# Altium schematic sheet #DISPLAY.SchDoc
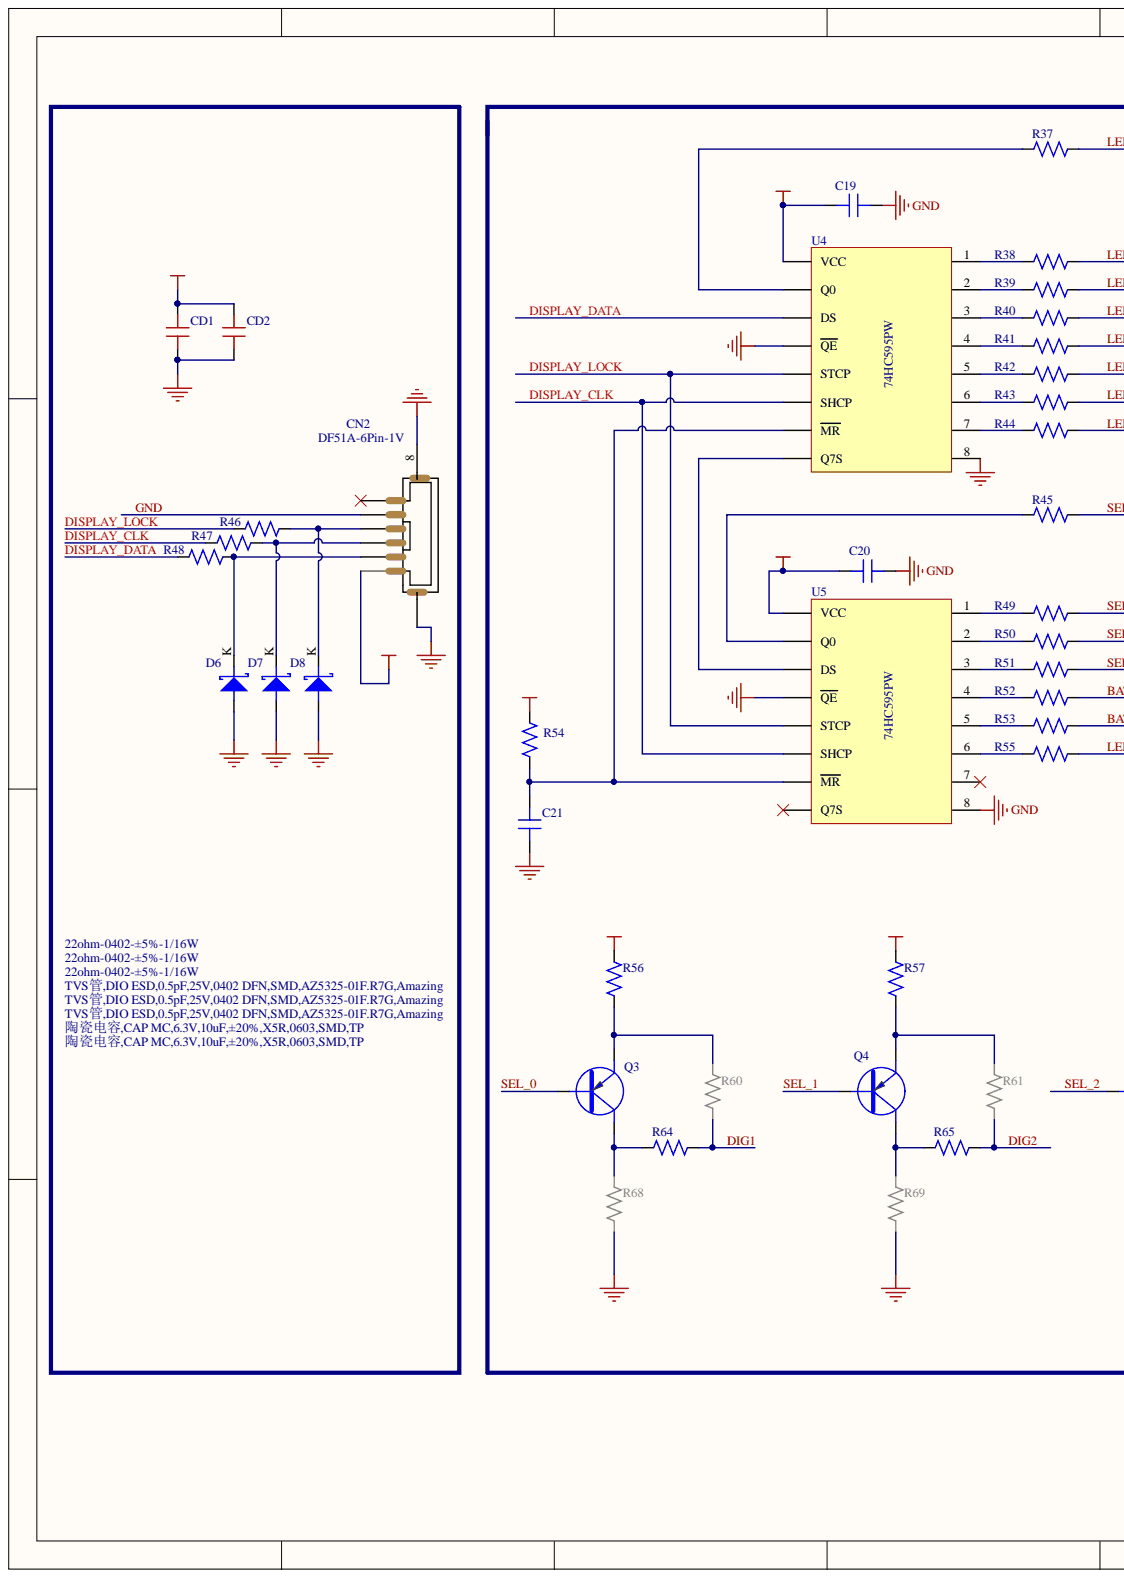
[diagram p0: #DISPLAY.SchDoc, left]
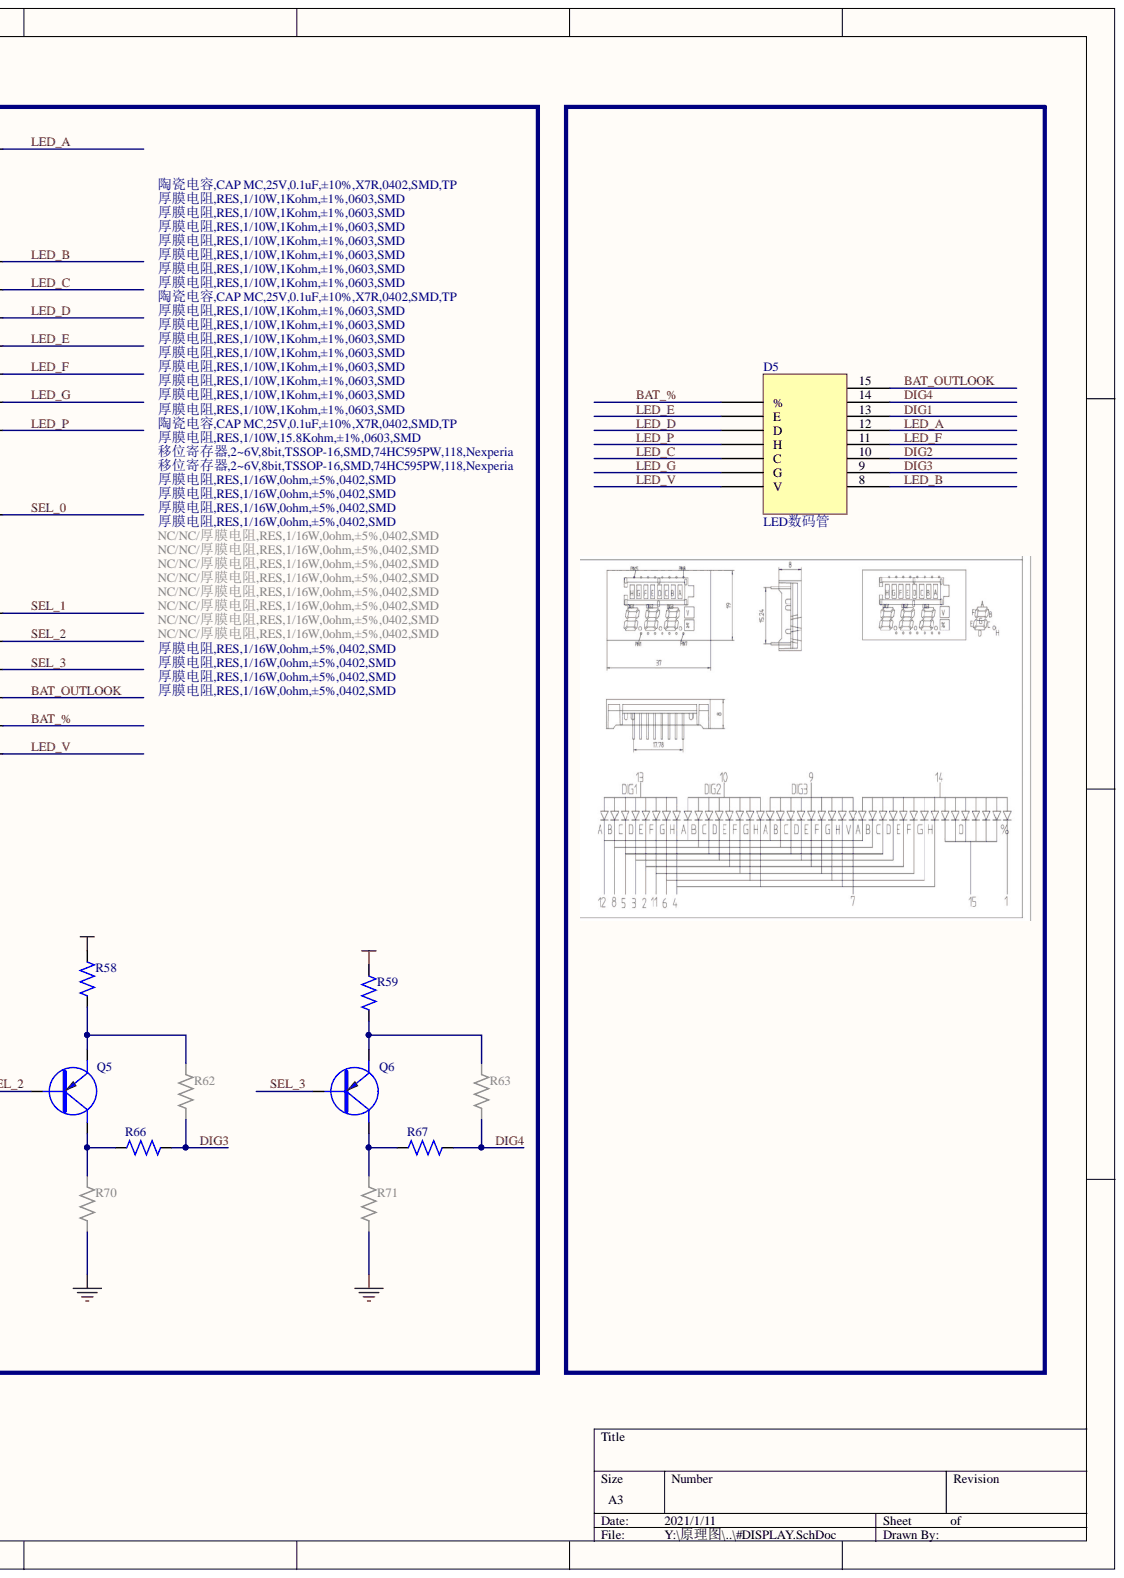
[diagram p1: #DISPLAY.SchDoc, right]

## Parts
C19  陶瓷电容,CAP MC,25V,0.1uF,±10%,X7R,0402,SMD,TP  [C0402]
C20  陶瓷电容,CAP MC,25V,0.1uF,±10%,X7R,0402,SMD,TP  [C0402]
C21  陶瓷电容,CAP MC,25V,0.1uF,±10%,X7R,0402,SMD,TP  [C0402]
CD1  陶瓷电容,CAP MC,6.3V,10uF,±20%,X5R,0603,SMD,TP  [C0603]
CD2  陶瓷电容,CAP MC,6.3V,10uF,±20%,X5R,0603,SMD,TP  [C0603]
CN2  DF51A-6Pin-1V  [SIP6V/1.0S-3]
D5  LED数码管  [LED]
D6  TVS管,DIO ESD,0.5pF,25V,0402 DFN,SMD,AZ5325-01F.R7G,Amazing  [DIODE-S0402]
D7  TVS管,DIO ESD,0.5pF,25V,0402 DFN,SMD,AZ5325-01F.R7G,Amazing  [DIODE-S0402]
D8  TVS管,DIO ESD,0.5pF,25V,0402 DFN,SMD,AZ5325-01F.R7G,Amazing  [DIODE-S0402]
Q3  通用三极管,Dynatron,PNP,0.2A,40V,30-100,SOT-23,MMBT3906-(100-300),BLUE ROCKET  [SOT-23]  (value not drawn)
Q4  通用三极管,Dynatron,PNP,0.2A,40V,30-100,SOT-23,MMBT3906-(100-300),BLUE ROCKET  [SOT-23]  (value not drawn)
Q5  通用三极管,Dynatron,PNP,0.2A,40V,30-100,SOT-23,MMBT3906-(100-300),BLUE ROCKET  [SOT-23]  (value not drawn)
Q6  通用三极管,Dynatron,PNP,0.2A,40V,30-100,SOT-23,MMBT3906-(100-300),BLUE ROCKET  [SOT-23]  (value not drawn)
R37  厚膜电阻,RES,1/10W,1Kohm,±1%,0603,SMD  [R0603]
R38  厚膜电阻,RES,1/10W,1Kohm,±1%,0603,SMD  [R0603]
R39  厚膜电阻,RES,1/10W,1Kohm,±1%,0603,SMD  [R0603]
R40  厚膜电阻,RES,1/10W,1Kohm,±1%,0603,SMD  [R0603]
R41  厚膜电阻,RES,1/10W,1Kohm,±1%,0603,SMD  [R0603]
R42  厚膜电阻,RES,1/10W,1Kohm,±1%,0603,SMD  [R0603]
R43  厚膜电阻,RES,1/10W,1Kohm,±1%,0603,SMD  [R0603]
R44  厚膜电阻,RES,1/10W,1Kohm,±1%,0603,SMD  [R0603]
R45  厚膜电阻,RES,1/10W,1Kohm,±1%,0603,SMD  [R0603]
R46  22ohm-0402-±5%-1/16W  [R0402]
R47  22ohm-0402-±5%-1/16W  [R0402]
R48  22ohm-0402-±5%-1/16W  [R0402]
R49  厚膜电阻,RES,1/10W,1Kohm,±1%,0603,SMD  [R0603]
R50  厚膜电阻,RES,1/10W,1Kohm,±1%,0603,SMD  [R0603]
R51  厚膜电阻,RES,1/10W,1Kohm,±1%,0603,SMD  [R0603]
R52  厚膜电阻,RES,1/10W,1Kohm,±1%,0603,SMD  [R0603]
R53  厚膜电阻,RES,1/10W,1Kohm,±1%,0603,SMD  [R0603]
R54  厚膜电阻,RES,1/10W,15.8Kohm,±1%,0603,SMD  [R0603]
R55  厚膜电阻,RES,1/10W,1Kohm,±1%,0603,SMD  [R0603]
R56  厚膜电阻,RES,1/16W,0ohm,±5%,0402,SMD  [R0402]
R57  厚膜电阻,RES,1/16W,0ohm,±5%,0402,SMD  [R0402]
R58  厚膜电阻,RES,1/16W,0ohm,±5%,0402,SMD  [R0402]
R59  厚膜电阻,RES,1/16W,0ohm,±5%,0402,SMD  [R0402]
R60  NC/NC/厚膜电阻,RES,1/16W,0ohm,±5%,0402,SMD  [R0402]
R61  NC/NC/厚膜电阻,RES,1/16W,0ohm,±5%,0402,SMD  [R0402]
R62  NC/NC/厚膜电阻,RES,1/16W,0ohm,±5%,0402,SMD  [R0402]
R63  NC/NC/厚膜电阻,RES,1/16W,0ohm,±5%,0402,SMD  [R0402]
R64  厚膜电阻,RES,1/16W,0ohm,±5%,0402,SMD  [R0402]
R65  厚膜电阻,RES,1/16W,0ohm,±5%,0402,SMD  [R0402]
R66  厚膜电阻,RES,1/16W,0ohm,±5%,0402,SMD  [R0402]
R67  厚膜电阻,RES,1/16W,0ohm,±5%,0402,SMD  [R0402]
R68  NC/NC/厚膜电阻,RES,1/16W,0ohm,±5%,0402,SMD  [R0402]
R69  NC/NC/厚膜电阻,RES,1/16W,0ohm,±5%,0402,SMD  [R0402]
R70  NC/NC/厚膜电阻,RES,1/16W,0ohm,±5%,0402,SMD  [R0402]
R71  NC/NC/厚膜电阻,RES,1/16W,0ohm,±5%,0402,SMD  [R0402]
U4  移位寄存器,2~6V,8bit,TSSOP-16,SMD,74HC595PW,118,Nexperia  [TSSOP16/0.65-2]
U5  移位寄存器,2~6V,8bit,TSSOP-16,SMD,74HC595PW,118,Nexperia  [TSSOP16/0.65-2]

## Nets
VCC: C19.1 C20.1 CD1.2 CD2.2 CN2.1 R54.2 R56.2 R57.2 R58.2 R59.2 U4.16(VCC) U5.16(VCC)
BAT_%: D5.1(%) R53.2
BAT_OUTLOOK: D5.15 R52.2
DIG1: D5.13 R60.1 R64.2
DIG2: D5.10 R61.1 R65.2
DIG3: D5.9 R62.1 R66.2
DIG4: D5.14 R63.1 R67.2
DISPLAY_CLK: R47.2 U4.11(SHCP) U5.11(SHCP)
DISPLAY_DATA: R48.2 U4.14(DS)
DISPLAY_LOCK: R46.2 U4.12(STCP) U5.12(STCP)
GND: C19.2 C20.2 C21.2 CD1.1 CD2.1 CN2.5 CN2.7 CN2.8 D6.A D7.A D8.A R68.1 R69.1 R70.1 R71.1 U4.8 U4.13(QE) U5.8 U5.13(QE)
LED_A: D5.12 R37.2
LED_B: D5.8 R38.2
LED_C: D5.5(C) R39.2
LED_D: D5.3(D) R40.2
LED_E: D5.2(E) R41.2
LED_F: D5.11 R42.2
LED_G: D5.6(G) R43.2
LED_P: D5.4(H) R44.2
LED_V: D5.7(V) R55.2
SEL_0: Q3.B R45.2
SEL_1: Q4.B R49.2
SEL_2: Q5.B R50.2
SEL_3: Q6.B R51.2
NetC21_1: C21.1 R54.1 U4.10(MR) U5.10(MR)
NetCN2_2: CN2.2 D6.K R48.1
NetCN2_3: CN2.3 D7.K R47.1
NetCN2_4: CN2.4 D8.K R46.1
NetQ3_C: Q3.C R64.1 R68.2
NetQ3_E: Q3.E R56.1 R60.2
NetQ4_C: Q4.C R65.1 R69.2
NetQ4_E: Q4.E R57.1 R61.2
NetQ5_C: Q5.C R66.1 R70.2
NetQ5_E: Q5.E R58.1 R62.2
NetQ6_C: Q6.C R67.1 R71.2
NetQ6_E: Q6.E R59.1 R63.2
NetR37_1: R37.1 U4.15(Q0)
NetR38_1: R38.1 U4.1
NetR39_1: R39.1 U4.2
NetR40_1: R40.1 U4.3
NetR41_1: R41.1 U4.4
NetR42_1: R42.1 U4.5
NetR43_1: R43.1 U4.6
NetR44_1: R44.1 U4.7
NetR45_1: R45.1 U5.15(Q0)
NetR49_1: R49.1 U5.1
NetR50_1: R50.1 U5.2
NetR51_1: R51.1 U5.3
NetR52_1: R52.1 U5.4
NetR53_1: R53.1 U5.5
NetR55_1: R55.1 U5.6
NetU4_9: U4.9(Q7S) U5.14(DS)

Not connected: CN2 6; U5 7 9(Q7S)

## Library data of the parts
C19-C21 = 0.1uF-0402-X5R-±20%-16V-D: Component Kind: Standard; Library Name: RCL.SchLib
CD1 CD2 = 004.003.0000383-D: 陶瓷电容,CAP MC,6.3V,10uF,±20%,X5R,0603,SMD,TP-D; Component Kind: Standard; Library Name: D_Cap.SchLib
CN2 D5 = Component_1: Component Kind: Standard
  CN2: Library Name: DF50A-6P-1V(51).SchLib
  D5: Library Name: LED.SchLib
D6-D8 = 004.005.0000435-D: TVS管,DIO ESD,0.5pF,25V,0402 DFN,SMD,AZ5325-01F.R7G,Amazing-D; Component Kind: Standard; Library Name: D_Semiconductor.SchLib
Q3-Q6 = 004.006.0000003-D: 通用三极管,Dynatron,PNP,0.2A,40V,30-100,SOT-23,MMBT3906-(100-300),BLUE ROCKET-D; Component Kind: Standard; Library Name: PS半导体器件.SchLib
R37-R45 R49-R53 R55 = 004.002.0000280-D: 厚膜电阻,RES,1/10W,1Kohm,±1%,0603,SMD-D; Component Kind: Standard; Library Name: RCL.SchLib
R46-R48 = 22ohm-0402-±5%-1/16W-D: Component Kind: Standard; Library Name: RCL.SchLib
R54 = 5K76ohm-0603-±1%-1/16W-D: Component Kind: Standard; Library Name: RCL.SchLib
R56-R71 = 004.002.0000558-D: 厚膜电阻,RES,1/16W,0ohm,±5%,0402,SMD-D; Component Kind: Standard; Library Name: D_Res.SchLib
U4 U5 = 004.017.0050011-D: 移位寄存器,2~6V,8bit,TSSOP-16,SMD,74HC595PW,118,Nexperia-D; Component Kind: Standard; Library Name: D_Semiconductor.SchLib
